# Altium schematic sheet OpenBCI Hyperscanning.SchDoc("OpenBCI Hyperscanning")
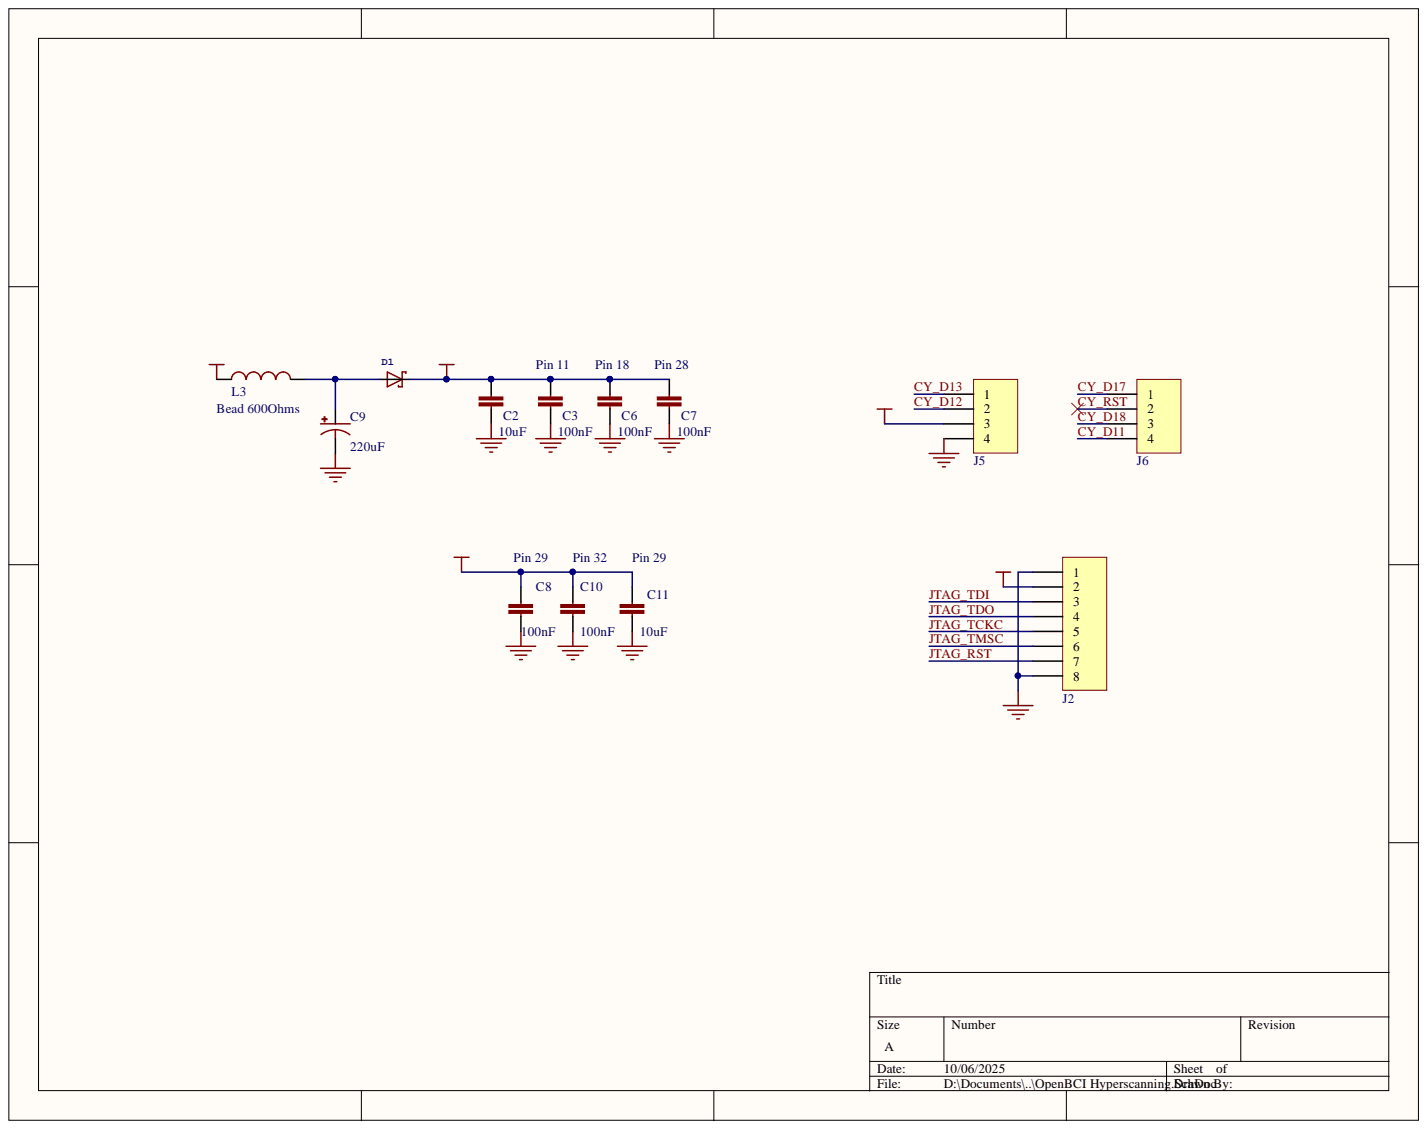
[diagram p0: OpenBCI Hyperscanning.SchDoc]

## Parts
C2  10uF  [C0603 - rounded]
C3  100nF  [C0402 - rounded]
C6  100nF  [C0402 - rounded]
C7  100nF  [C0402 - rounded]
C8  100nF  [C0402 - rounded]
C9  220uF  [SMC_B]
C10  100nF  [C0402 - rounded]
C11  10uF  [C0603 - rounded]
D1  Schottky Diode  [SOD523]  (value not drawn)
J2  08p  [61300811121]  (value not drawn)
J5  04p  [61300411121]  (value not drawn)
J6  04p  [61300411121]  (value not drawn)
L3  value Bead 600Ohms  [L0603]  (hidden comment: Ferrite bead 0603)

## Nets
3V3: J2.2 J5.3 L3.1
GND: C2.2 C3.2 C6.2 C7.2 C8.2 C9.- C10.2 C11.2 J2.1 J2.8 J5.4
VDDR: C8.1 C10.1 C11.1
VDDS: C2.1 C3.1 C6.1 C7.1 D1.C
CY_D11: J6.4
CY_D12: J5.2
CY_D13: J5.1
CY_D17: J6.1
CY_D18: J6.3
CY_RST: J6.2
JTAG_RST: J2.7
JTAG_TCKC: J2.5
JTAG_TDI: J2.3
JTAG_TDO: J2.4
JTAG_TMSC: J2.6
NetC9_+: C9.+ D1.A L3.2

## Library data of the parts
C2 C3 C6-C8 C10 C11 = C-EU-SMD: Generic Capacitor SMD; Library Name: rcl.IntLib; Component Kind: Standard
  C2 C11: Value: 10uF
  C3 C6-C8 C10: Value: 100nF
C9 = CPOL-TANT: Generic Tantalum Capacitor; Value: 220uF; Library Name: rcl.IntLib; Component Kind: Standard
D1 = SCHOTTKY-DIODEDO201T15: Part Number: PMEG2010AEB,115; Vendor: Nexperia; Familia: Diodos; Library Name: diode.IntLib; Description: Schottky Diode; Component Kind: Standard
J2 = 61300811021: WR-PHD 2.54 mm THT Angled Pin Header, 08p; Vendor: Wurth Elektronik; Value: 08p; Part Number: 61300811021; Library Name: Header-254mm.IntLib; Component Kind: Standard
J5 J6 = 61300411121: WR-PHD 2.54 mm THT Pin Header, 04p; Vendor: Wurth Elektronik; Value: 04p; Part Number: 61300411121; Library Name: Header-254mm.IntLib; Component Kind: Standard
L3 = L-US0603: Ferrite Beads 0603 600ohms; Part Number: MPZ1608S601ATA00; Vendor: Murata; Familia: Indutores; Library Name: rcl.IntLib; Component Kind: Standard
